# Altium schematic sheet 2. MICROBUS&Connectors.SchDoc
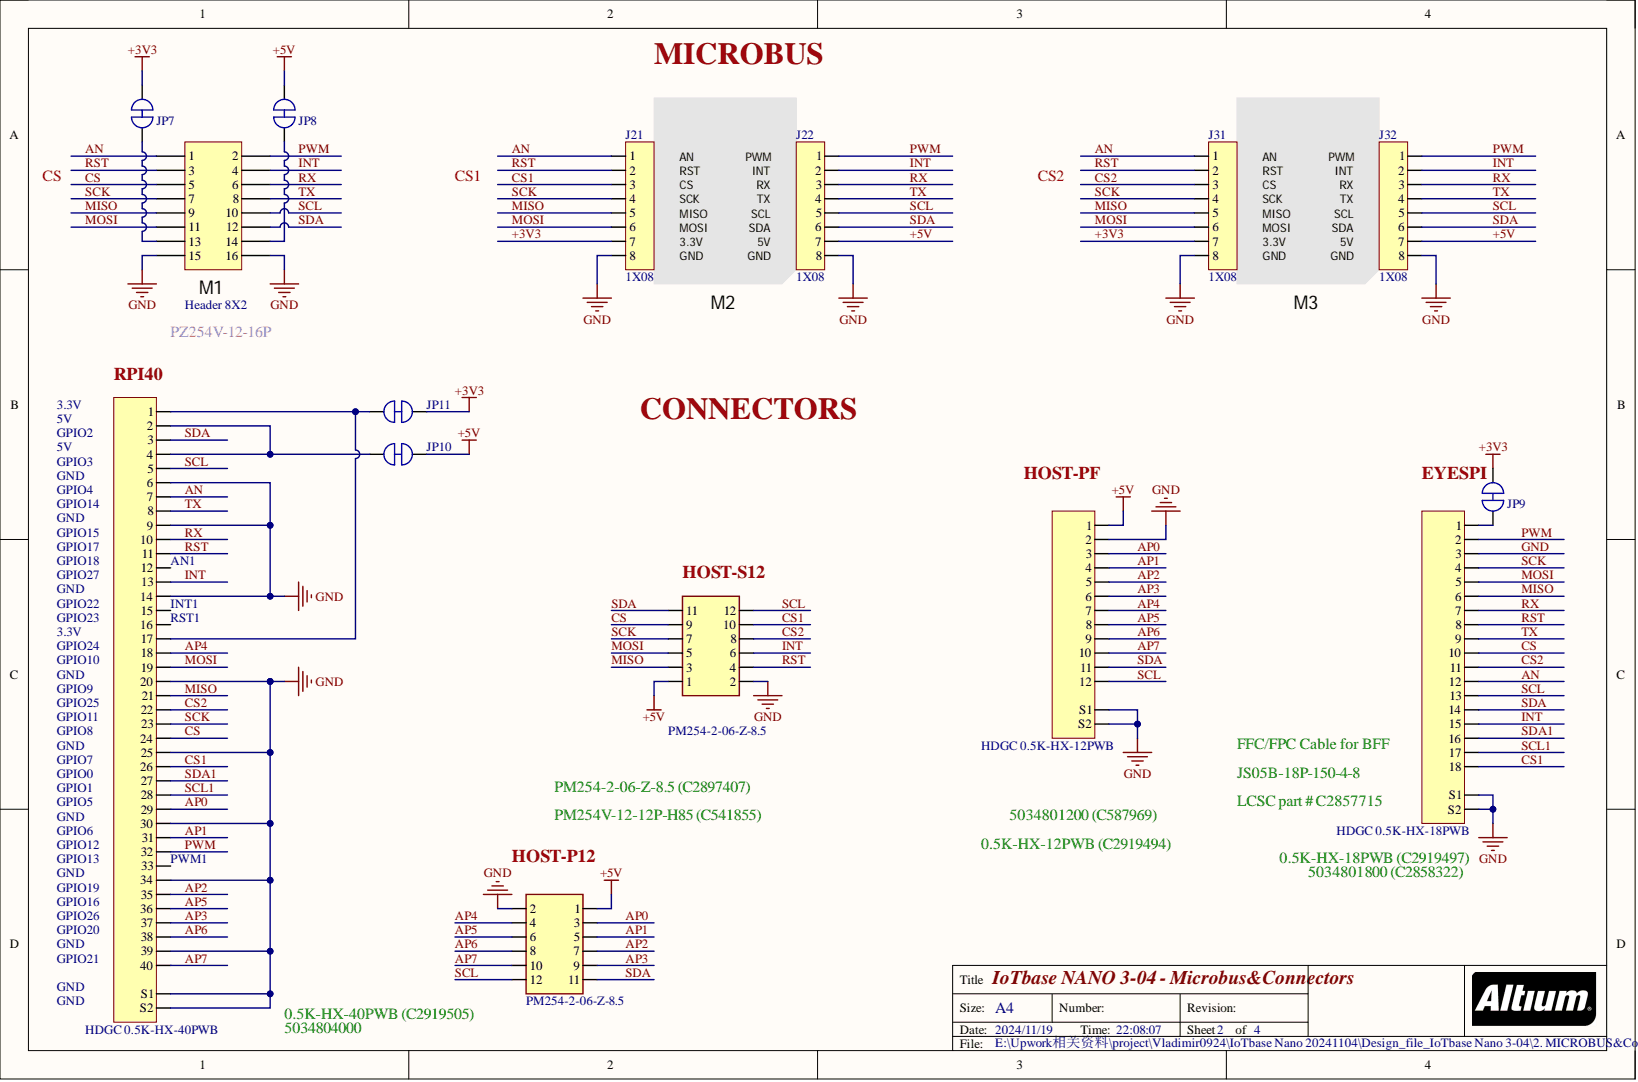
[diagram p0: 2. MICROBUS&Connectors.SchDoc]

## Parts
EYESPI  HDGC 0.5K-HX-18PWB  [MOLX-503480-1800]
HOST-P12  PM254-2-06-Z-8.5  [PBD-12]
HOST-PF  HDGC 0.5K-HX-12PWB  [MOLX-503480-1200]
HOST-S12  PM254-2-06-Z-8.5  [PBD-12]
J21  1X08  [CON_1X08_2.54MM_FEMALE_TH_STRAIGHT]
J22  1X08  [CON_1X08_2.54MM_FEMALE_TH_STRAIGHT]
J31  1X08  [CON_1X08_2.54MM_FEMALE_TH_STRAIGHT]
J32  1X08  [CON_1X08_2.54MM_FEMALE_TH_STRAIGHT]
JP7  SOLDER_JUMPER_NC  [SOLDER_JUMPER_NC]  (value not drawn)  (no BOM)
JP8  SOLDER_JUMPER_NC  [SOLDER_JUMPER_NC]  (value not drawn)  (no BOM)
JP9  SOLDER_JUMPER_NC  [SOLDER_JUMPER_NC]  (value not drawn)  (no BOM)
JP10  SOLDER_JUMPER_NC  [SOLDER_JUMPER_NC]  (value not drawn)  (no BOM)
JP11  SOLDER_JUMPER_NC  [SOLDER_JUMPER_NC]  (value not drawn)  (no BOM)
M1  Header 8X2  [PLD-16]
M2  MIKROBUS HOST  [MIKROBUS_HOST]  (value not drawn)  (no BOM)
M3  MIKROBUS HOST  [MIKROBUS_HOST]  (value not drawn)  (no BOM)
RPI40  HDGC 0.5K-HX-40PWB  [FP-503480-4000-MFG]

## Nets
+3V3: J21.7 J31.7 JP7.1 JP9.1 JP11.2
+5V: HOST-P12.1 HOST-PF.1 HOST-S12.1 J22.7 J32.7 JP8.1 JP10.2
AN: EYESPI.12 J21.1 J31.1 M1.1 RPI40.7
AP0: HOST-P12.3 HOST-PF.3 RPI40.29
AP1: HOST-P12.5 HOST-PF.4 RPI40.31
AP2: HOST-P12.7 HOST-PF.5 RPI40.35
AP3: HOST-P12.9 HOST-PF.6 RPI40.37
AP4: HOST-P12.4 HOST-PF.7 RPI40.18
AP5: HOST-P12.6 HOST-PF.8 RPI40.36
AP6: HOST-P12.8 HOST-PF.9 RPI40.38
AP7: HOST-P12.10 HOST-PF.10 RPI40.40
CS: EYESPI.10 HOST-S12.9 M1.5 RPI40.24
CS1: EYESPI.18 HOST-S12.10 J21.3 RPI40.26
CS2: EYESPI.11 HOST-S12.8 J31.3 RPI40.22
GND: EYESPI.3 EYESPI.S1 EYESPI.S2 HOST-P12.2 HOST-PF.2 HOST-PF.S1 HOST-PF.S2 HOST-S12.2 J21.8 J22.8 J31.8 J32.8 M1.15 M1.16 RPI40.6 RPI40.9 RPI40.14 RPI40.20 RPI40.25 RPI40.30 RPI40.34 RPI40.39 RPI40.S1 RPI40.S2
INT: EYESPI.15 HOST-S12.6 J22.2 J32.2 M1.4 RPI40.13
MISO: EYESPI.6 HOST-S12.3 J21.5 J31.5 M1.9 RPI40.21
MOSI: EYESPI.5 HOST-S12.5 J21.6 J31.6 M1.11 RPI40.19
PWM: EYESPI.2 J22.1 J32.1 M1.2 RPI40.32
RST: EYESPI.8 HOST-S12.4 J21.2 J31.2 M1.3 RPI40.11
RX: EYESPI.7 J22.3 J32.3 M1.6 RPI40.10
SCK: EYESPI.4 HOST-S12.7 J21.4 J31.4 M1.7 RPI40.23
SCL: EYESPI.13 HOST-P12.12 HOST-PF.12 HOST-S12.12 J22.5 J32.5 M1.10 RPI40.5
SCL1: EYESPI.17 RPI40.28
SDA: EYESPI.14 HOST-P12.11 HOST-PF.11 HOST-S12.11 J22.6 J32.6 M1.12 RPI40.3
SDA1: EYESPI.16 RPI40.27
TX: EYESPI.9 J22.4 J32.4 M1.8 RPI40.8
NetEYESPI_1: EYESPI.1 JP9.2
NetJP7_2: JP7.2 M1.13
NetJP8_2: JP8.2 M1.14
NetJP10_1: JP10.1 RPI40.2 RPI40.4
NetJP11_1: JP11.1 RPI40.1 RPI40.17

Not connected: RPI40 12 15 16 33

## Library data of the parts
HOST-P12 HOST-S12 = DS1023-2x6SF11: 2.54mm Female Header  V/T Type, 2x06 Pin; Component Kind: Standard; Value: PM254-2-06-Z-8.5; Library Name: IoTextra_Input_2-03.SCHLIB
J21 J22 J31 J32 = CON_1X08_2.54MM_FEMALE_TH_STRAIGHT: 2.54mm Plugin 8P Straight 1x8P Plugin,P=2.54mm Female Headers ROHS; Manufacturer: BOOMELE(Boom Precision Elec); Manufacturer Part Number: C27438; Component Kind: Standard; Library Name: Schematic_Lib.SchLib; Supplier 1: LCSC; Supplier Part Number 1: C27438
JP7-JP11 = SOLDER_JUMPER_NC: Solder Jumper; Library Name: Schematic_Lib.SchLib
M1 = Header 8X2: Straight Square Pins 2.5mm 16P 6mm -40℃~+105℃ 3mm 2.54mm 双排 Black Brass 2x8P 2.54mm Plugin,P=2.54mm Pin Headers ROHS; Manufacturer: XFCN; Manufacturer Part Number: PZ254V-12-16P; Component Kind: Standard; Library Name: Miscellaneous Connectors.IntLib; Signal Integrity: Connector; Supplier 1: LCSC; Supplier Part Number 1: C492425
M2 M3 = MIKROBUS_HOST: mikroBUS Host Socket; Library Name: Schematic_Lib.SchLib
EYESPI = HDGC 0.5K-HX-18PWB: Component Kind: Standard; Description: HDGC 0.5K-HX-18PWB; Library Name: IoTextra_Input_2-03.SCHLIB
HOST-PF = HDGC 0.5K-HX-12PWB: Component Kind: Standard; Description: HDGC 0.5K-HX-12PWB; Library Name: IoTextra_Input_2-03.SCHLIB
RPI40 = HDGC 0.5K-HX-40PWB: Component Kind: Standard; Description: HDGC 0.5K-HX-40PWB; Library Name: IoTextra_Input_2-03.SCHLIB
